# Altium schematic sheet CW308T_LPC55S69_Micro.SchDoc
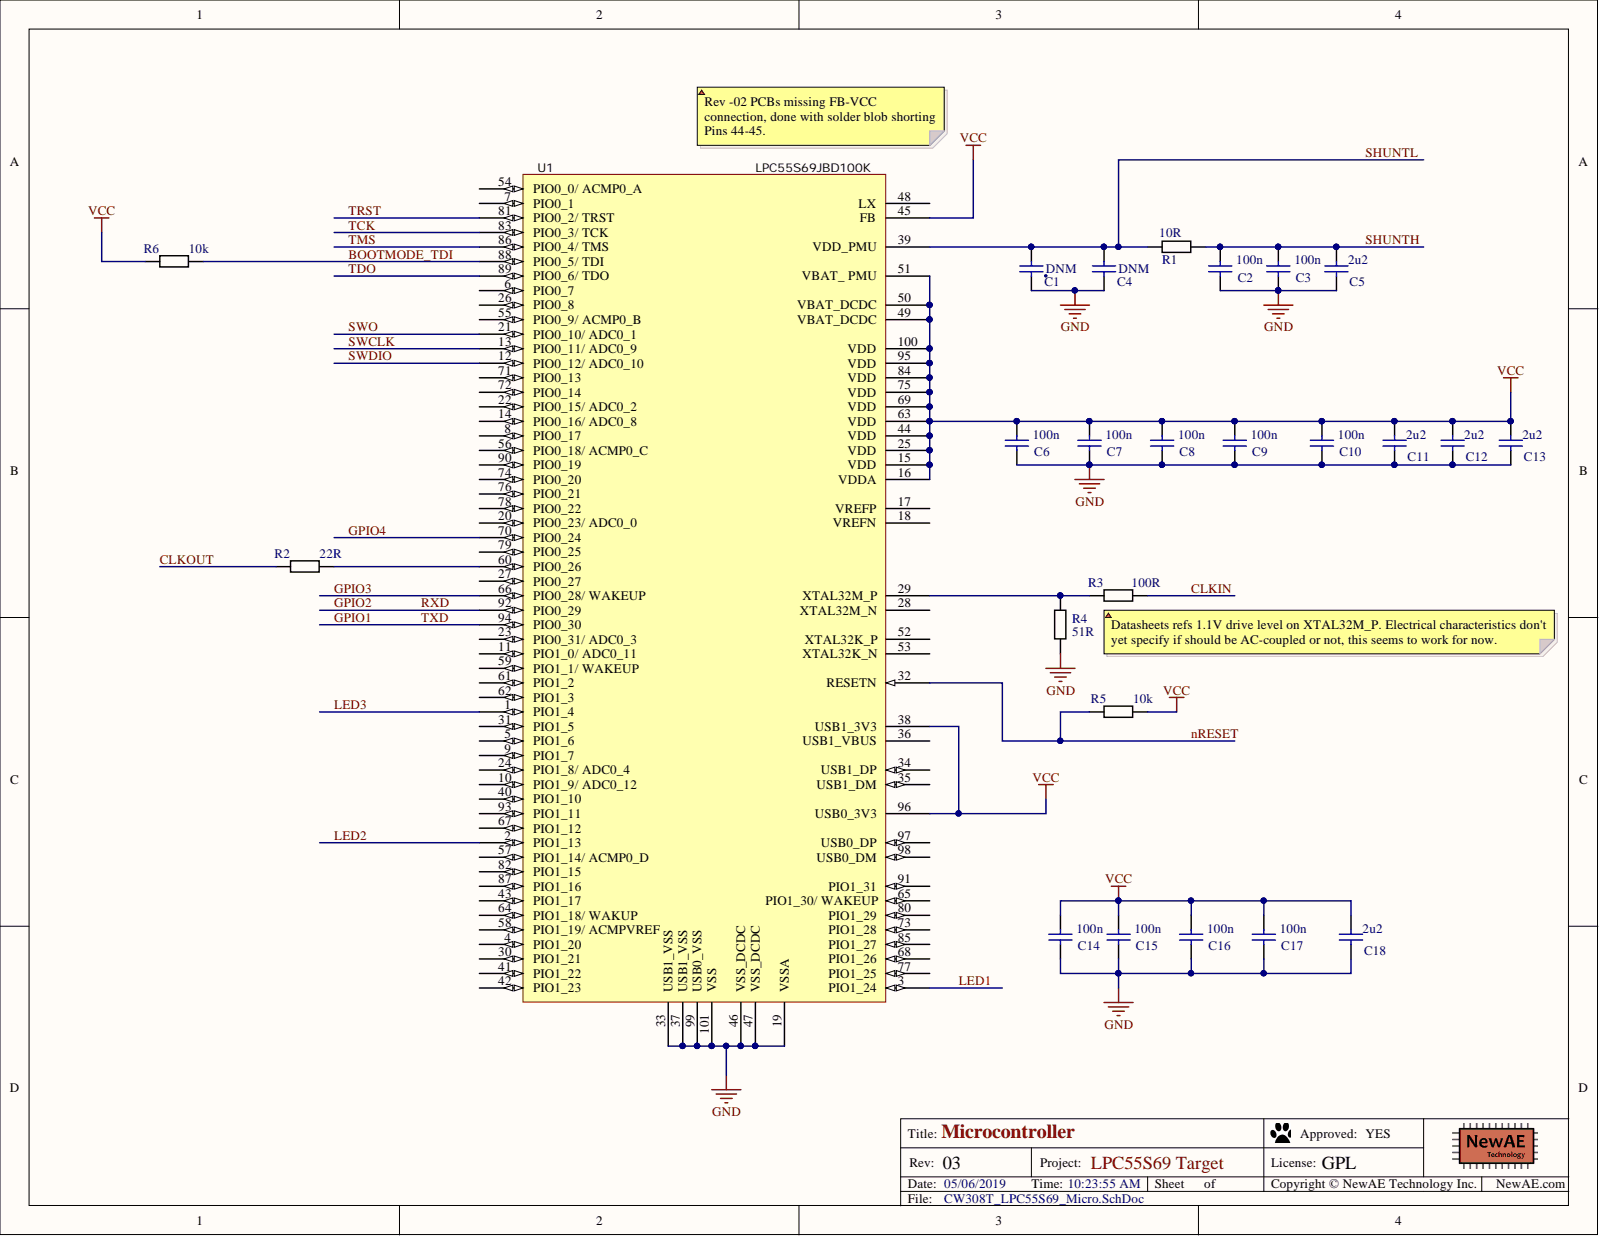
[diagram p0: CW308T_LPC55S69_Micro.SchDoc]

## Parts
C1  DNM  [0603]
C2  100n  [0603]
C3  100n  [0603]
C4  DNM  [0603]
C5  2u2  [0603]
C6  100n  [0603]
C7  100n  [0603]
C8  100n  [0603]
C9  100n  [0603]
C10  100n  [0603]
C11  2u2  [0603]
C12  2u2  [0603]
C13  2u2  [0603]
C14  100n  [0603]
C15  100n  [0603]
C16  100n  [0603]
C17  100n  [0603]
C18  2u2  [0603]
R1  10R  [0603]
R2  22R  [0603]
R3  100R  [0603]
R4  51R  [0603]
R5  10k  [0603]
R6  10k  [0603]
U1  LPC55S69JBD100K  [TQFP100]

## Nets
GND: C1.2 C2.2 C3.2 C4.2 C5.2 C6.2 C7.2 C8.2 C9.2 C10.2 C11.2 C12.2 C13.2 C14.2 C15.2 C16.2 C17.2 C18.2 R4.2 U1.19(VSSA) U1.33(USB1_VSS) U1.37(USB1_VSS) U1.46(VSS_DCDC) U1.47(VSS_DCDC) U1.99(USB0_VSS) U1.101(VSS)
VCC: C6.1 C7.1 C8.1 C9.1 C10.1 C11.1 C12.1 C13.1 C14.1 C15.1 C16.1 C17.1 C18.1 R5.2 R6.1 U1.15(VDD) U1.16(VDDA) U1.25(VDD) U1.38(USB1_3V3) U1.44(VDD) U1.45(FB) U1.49(VBAT_DCDC) U1.50(VBAT_DCDC) U1.51(VBAT__PMU) U1.63(VDD) U1.69(VDD) U1.75(VDD) U1.84(VDD) U1.95(VDD) U1.96(USB0_3V3) U1.100(VDD)
BOOTMODE_TDI: R6.2 U1.88
CLKIN: R3.2
CLKOUT: R2.1
GPIO1: U1.94
GPIO2: U1.92
GPIO3: U1.66
GPIO4: U1.70
LED1: U1.3(PIO1_24)
LED2: U1.2(PIO1_13)
LED3: U1.1(PIO1_4)
nRESET: R5.1 U1.32(RESETN)
SHUNTH: C2.1 C3.1 C5.1 R1.2
SHUNTL: C1.1 C4.1 R1.1 U1.39(VDD_PMU)
SWCLK: U1.13
SWDIO: U1.12
SWO: U1.21
TCK: U1.83
TDO: U1.89
TMS: U1.86
TRST: U1.81
NetR2_2: R2.2 U1.60
NetR3_1: R3.1 R4.1 U1.29(XTAL32M_P)

Not connected: U1 4(PIO1_20) 5(PIO1_6) 6 7 8 9(PIO1_7) 10(PIO1_9/_ADC0_12) 11(PIO1_0/_ADC0_11) 14 17(VREFP) 18(VREFN) 20 22 23 24(PIO1_8/_ADC0_4) 26 27 28(XTAL32M_N) 30(PIO1_21) 31(PIO1_5) 34(USB1_DP) 35(USB1_DM) 36(USB1_VBUS) 40(PIO1_10) 41(PIO1_22) 42(PIO1_23) 43(PIO1_17) 48(LX) 52(XTAL32K_P) 53(XTAL32K_N) 54 55 56 57(PIO1_14/_ACMP0_D) 58(PIO1_19/_ACMPVREF) 59(PIO1_1/_WAKEUP) 61(PIO1_2) 62(PIO1_3) 64(PIO1_18/_WAKUP) 65(PIO1_30/_WAKEUP) 67(PIO1_12) 68(PIO1_26) 71 72 73(PIO1_28) 74 76 77(PIO1_25) 78 79 80(PIO1_29) 82(PIO1_15) 85(PIO1_27) 87(PIO1_16) 90 91(PIO1_31) 93(PIO1_11) 97(USB0_DP) 98(USB0_DM)

## Library data of the parts
C1 C4 = CCHIP-DNM-0603: DNM, 0603; Component Group: Capacitor; Database Table Name: main; Case-EIA: 0603; Component Kind: Standard; Component Type: Standard; Library Name: NewAE_AltiumLib.DBLib; Mounting Technology: SMT; Part Number: CCHIP-DNM-0603; Pin Count: 2; Value: DNM
C2 C3 C6-C10 C14-C17 = CCHIP-100n-0603: 100n, 0603; Manufacture 1: Kemet; Manufacture Part Number 1: C0603C104K5RACTU; Case-Metric: 1608; Component Group: Capacitor; Database Table Name: main; Rated Voltage: 50VDC; Case-EIA: 0603; Component Kind: Standard; Component Type: Standard; Library Name: NewAE_AltiumLib.DBLib; Mounting Technology: SMT; Part Number: CCHIP-100n-0603; Pin Count: 2; Supplier 1: Digi-Key; Supplier Part Number 1: 399-5089-2-ND; Value: 100n
C5 C11-C13 C18 = CCHIP-2u2-0603: 2u2, 0603; Manufacture 1: Samsung; Manufacture Part Number 1: CL10B225KP8NNNC; Case-Metric: 1608; Component Group: Capacitor; Database Table Name: main; Rated Voltage: 10VDC; Case-EIA: 0603; Component Kind: Standard; Component Type: Standard; Library Name: NewAE_AltiumLib.DBLib; Mounting Technology: SMT; Part Number: CCHIP-2u2-0603; Pin Count: 2; Supplier 1: Digi-Key; Supplier Part Number 1: 1276-1134-2-ND; Value: 2u2
R1 = RCHIP-10R-0603: 10R res, 0603, 1%, 1/10W; Manufacture 1: Yageo; Manufacture Part Number 1: RC0603FR-0710RL; Case-Metric: 1608; Component Group: Resistor; Database Table Name: main; Rated Power: 0.1W; Rated Voltage: 75VDC; Case-EIA: 0603; Component Kind: Standard; Component Type: Standard; Library Name: NewAE_AltiumLib.DBLib; Mounting Technology: SMT; Part Number: RCHIP-10R-0603; Pin Count: 2; Supplier 1: Digi-Key; Supplier Part Number 1: 311-10.0HRCT-ND; Temperature Range: -55C to 155C; Tolerance: 1%; Value: 10R
R2 = RCHIP-22R-0603: 22R res, 0603, 1%, 1/10W; Manufacture 1: Yageo; Manufacture Part Number 1: RC0603FR-0722RL; Case-Metric: 1608; Component Group: Resistor; Database Table Name: main; Rated Power: 0.1W; Rated Voltage: 75VDC; Case-EIA: 0603; Component Kind: Standard; Component Type: Standard; Library Name: NewAE_AltiumLib.DBLib; Mounting Technology: SMT; Part Number: RCHIP-22R-0603; Pin Count: 2; Supplier 1: Digi-Key; Supplier Part Number 1: 311-22.0HRCT-ND; Temperature Range: -55C to 155C; Tolerance: 1%; Value: 22R
R3 = RCHIP-100R-0603: 100R res, 0603, 1%, 1/10W; Manufacture 1: Yageo; Manufacture Part Number 1: RC0603FR-07100RL; Case-Metric: 1608; Component Group: Resistor; Database Table Name: main; Rated Power: 0.1W; Rated Voltage: 75VDC; Case-EIA: 0603; Component Kind: Standard; Component Type: Standard; Library Name: NewAE_AltiumLib.DBLib; Mounting Technology: SMT; Part Number: RCHIP-100R-0603; Pin Count: 2; Supplier 1: Digi-Key; Supplier Part Number 1: 311-100HRCT-ND; Temperature Range: -55C to 155C; Tolerance: 1%; Value: 100R
R4 = RCHIP-51R-0603: 51R res, 0603, 1%, 1/10W; Manufacture 1: Yageo; Manufacture Part Number 1: RC0603FR-0751RL; Case-Metric: 1608; Component Group: Resistor; Database Table Name: main; Rated Power: 0.1W; Rated Voltage: 75VDC; Case-EIA: 0603; Component Kind: Standard; Component Type: Standard; Library Name: NewAE_AltiumLib.DBLib; Mounting Technology: SMT; Part Number: RCHIP-51R-0603; Pin Count: 2; Supplier 1: Digi-Key; Supplier Part Number 1: 311-51.0HRCT-ND; Temperature Range: -55C to 155C; Tolerance: 1%; Value: 51R
R5 R6 = RCHIP-10K-0603: 10k res, 0603, 1%, 1/10W; Manufacture 1: Yageo; Manufacture Part Number 1: RC0603FR-0710KL; Case-Metric: 1608; Component Group: Resistor; Database Table Name: main; Rated Power: 0.1W; Rated Voltage: 75VDC; Case-EIA: 0603; Component Kind: Standard; Component Type: Standard; Library Name: NewAE_AltiumLib.DBLib; Mounting Technology: SMT; Part Number: RCHIP-10K-0603; Pin Count: 2; Supplier 1: Digi-Key; Supplier Part Number 1: 311-10.0KHRCT-ND; Temperature Range: -55C to 155C; Tolerance: 1%; Value: 10k
U1 = LPC55S69JBD100K: Cortex-m33, dual-core, security; Manufacture 1: NXP; Company_PN: <Enter Company P/N>; Component Group: Digital IC; Database Table Name: main; PP Material Stack: 0; Component Kind: Standard; Component Type: Standard; Library Name: NewAE_AltiumLib.DBLib; Manufacture Part Number 1: LPC55S69JBD100K; Part Number: LPC55S69JBD100K; Pin Count: 101; PP Rotation: 0; Created By: D.GODDARD
